# Altium schematic sheet AMS_IMD_Latching.SchDoc(AMS_IMD_Latching)
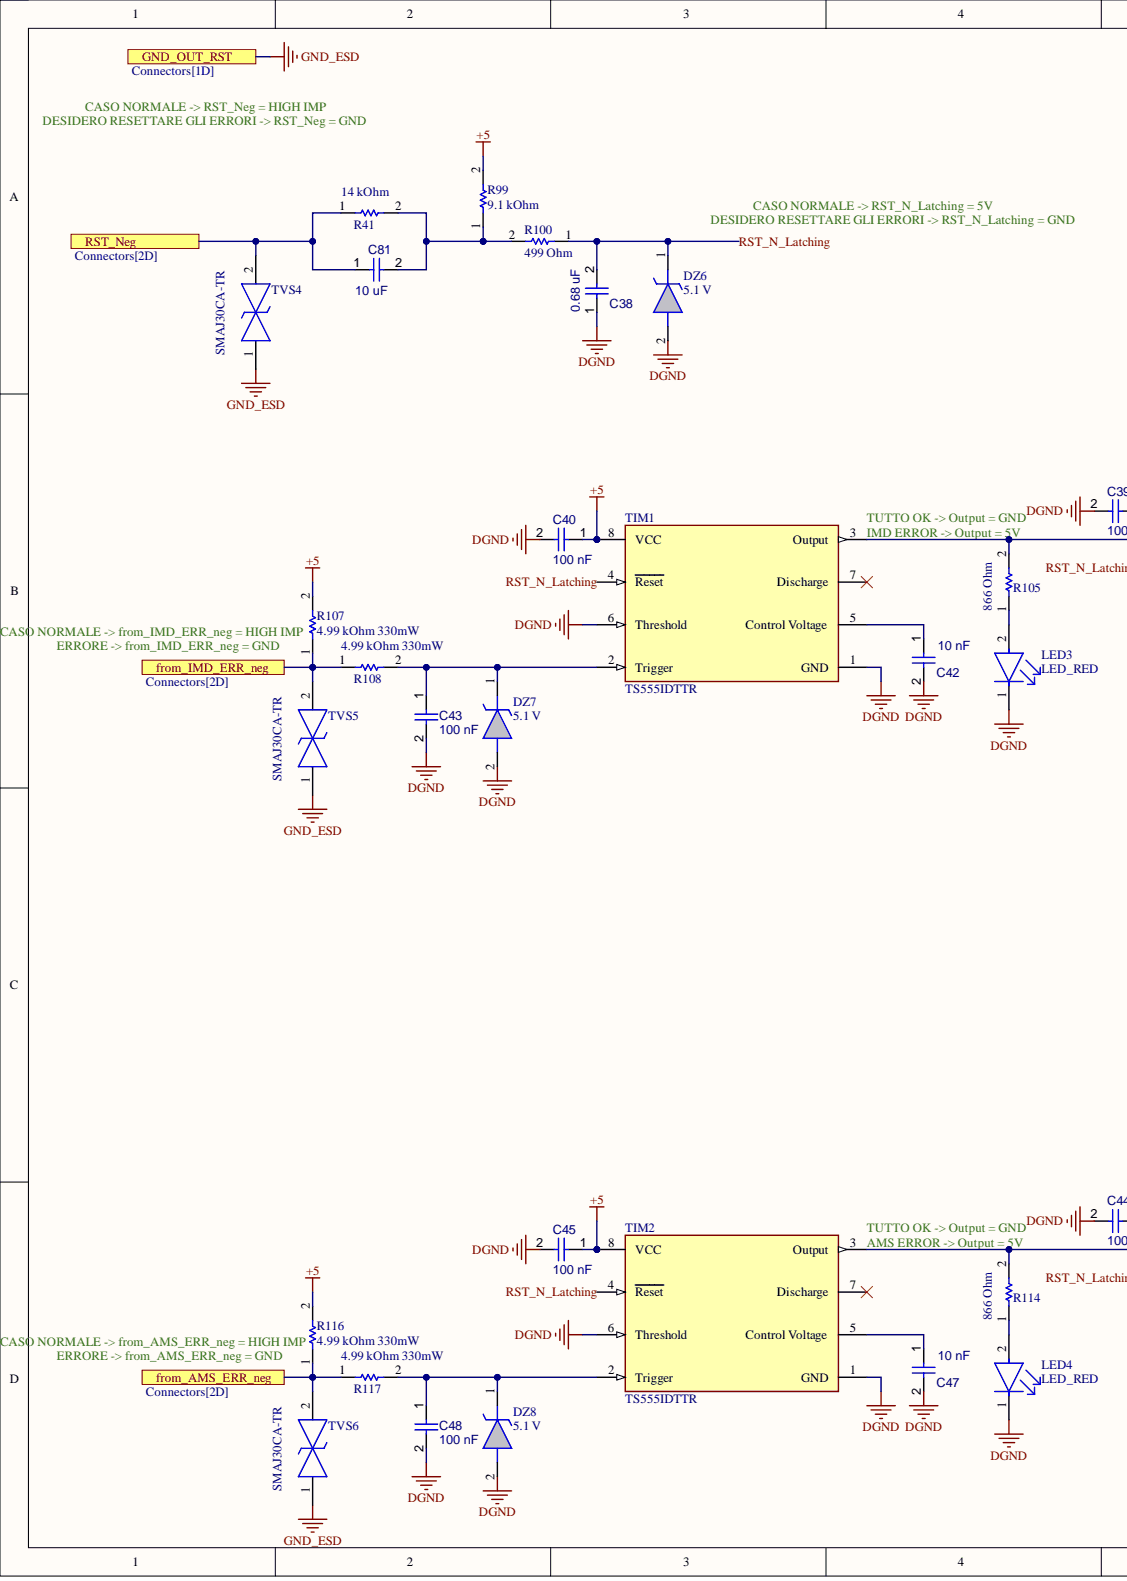
[diagram p0: AMS_IMD_Latching.SchDoc, left]
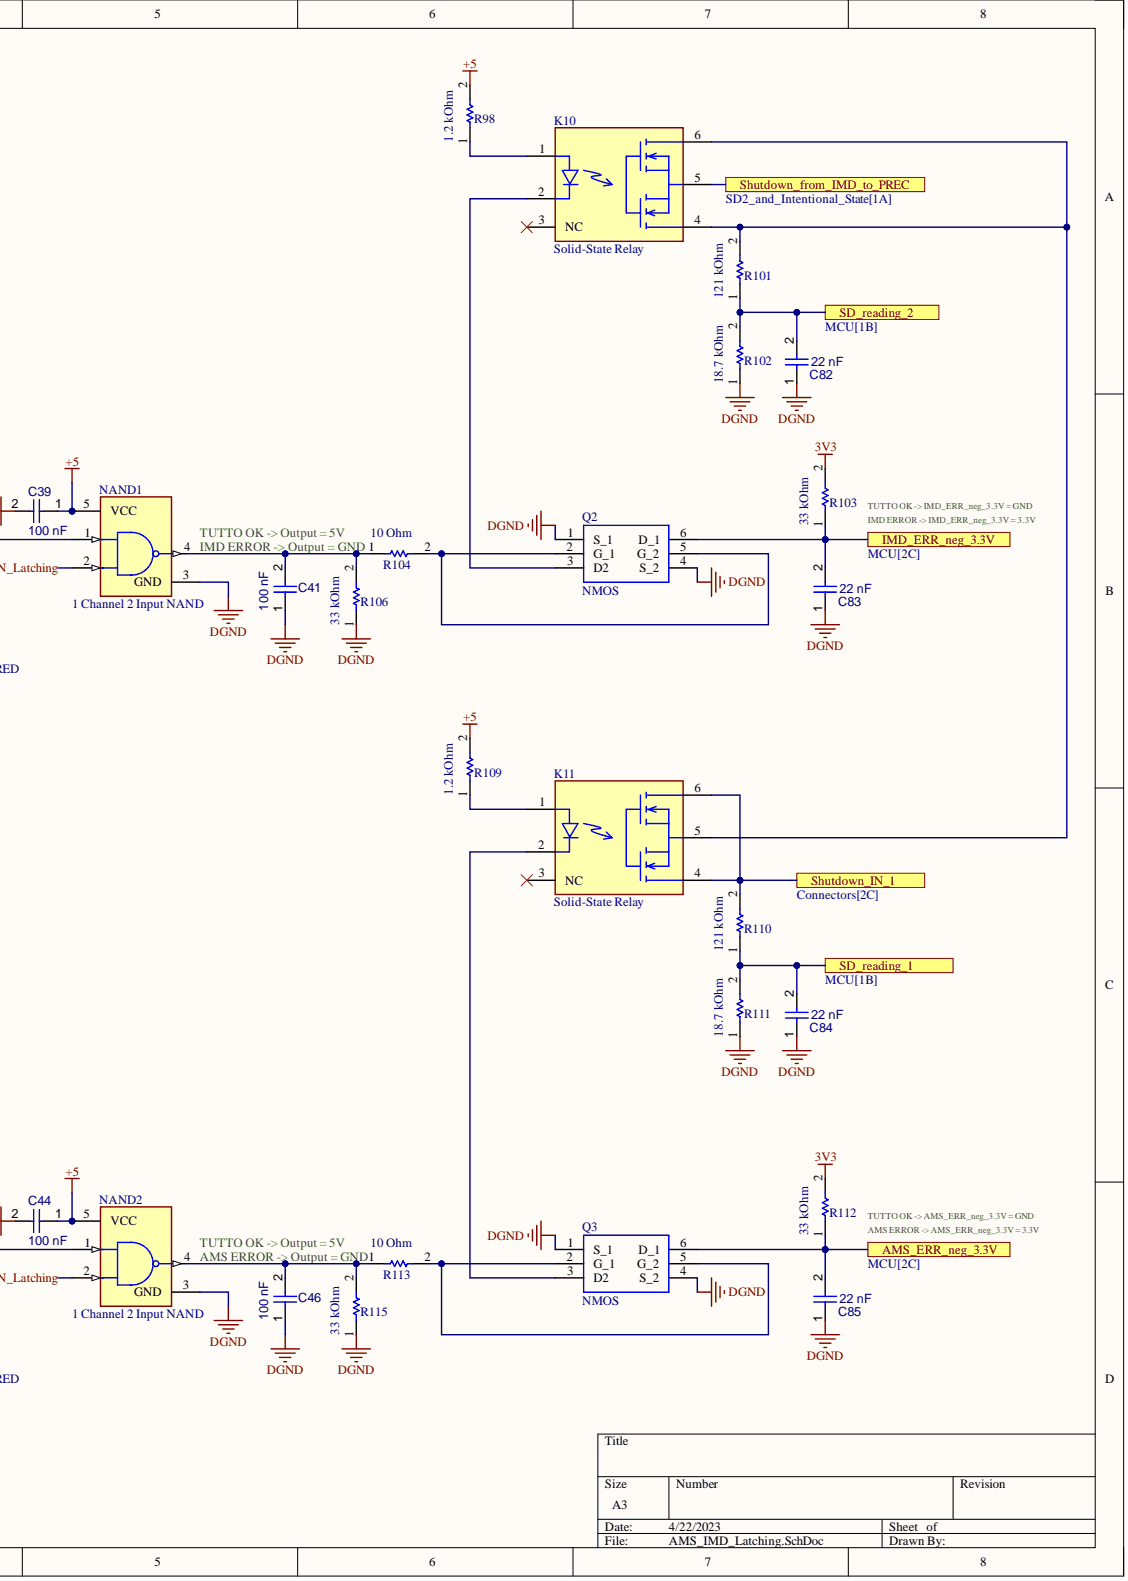
[diagram p1: AMS_IMD_Latching.SchDoc, right]

## Parts
C38  0.68 uF
C39  100 nF
C40  100 nF
C41  100 nF
C42  10 nF
C43  100 nF
C44  100 nF
C45  100 nF
C46  100 nF
C47  10 nF
C48  100 nF
C81  10 uF
C82  22 nF
C83  22 nF
C84  22 nF
C85  22 nF
DZ6  5.1 V
DZ7  5.1 V
DZ8  5.1 V
K10  Solid-State Relay  Category: Relay
K11  Solid-State Relay  Category: Relay
LED3  LED_RED
LED4  LED_RED
NAND1  1 Channel 2 Input NAND
NAND2  1 Channel 2 Input NAND
Q2  NMOS
Q3  NMOS
R41  14 kOhm
R98  1.2 kOhm
R99  9.1 kOhm
R100  499 Ohm
R101  121 kOhm
R102  18.7 kOhm
R103  33 kOhm
R104  10 Ohm
R105  866 Ohm
R106  33 kOhm
R107  4.99 kOhm 330mW
R108  4.99 kOhm 330mW
R109  1.2 kOhm
R110  121 kOhm
R111  18.7 kOhm
R112  33 kOhm
R113  10 Ohm
R114  866 Ohm
R115  33 kOhm
R116  4.99 kOhm 330mW
R117  4.99 kOhm 330mW
TIM1  TS555IDTTR
TIM2  TS555IDTTR
TVS4  SMAJ30CA-TR
TVS5  SMAJ30CA-TR
TVS6  SMAJ30CA-TR

## Ports
AMS_ERR_neg_3.3V, from_AMS_ERR_neg, from_IMD_ERR_neg, GND_OUT_RST, IMD_ERR_neg_3.3V, RST_Neg, SD_reading_1, SD_reading_2, Shutdown_from_IMD_to_PREC, Shutdown_IN_1

## Nets
3V3: R103.2 R112.2
+5: C39.1 C40.1 C44.1 C45.1 NAND1.5(VCC) NAND2.5(VCC) R98.2 R99.2 R107.2 R109.2 R116.2 TIM1.8(VCC) TIM2.8(VCC)
DGND: C38.1 C39.2 C40.2 C41.1 C42.2 C43.2 C44.2 C45.2 C46.1 C47.2 C48.2 C82.1 C83.1 C84.1 C85.1 DZ6.2 DZ7.2 DZ8.2 LED3.1 LED4.1 NAND1.3(GND) NAND2.3(GND) Q2.1(S_1) Q2.4(S_2) Q3.1(S_1) Q3.4(S_2) R102.1 R106.1 R111.1 R115.1 TIM1.1(GND) TIM1.6(Threshold) TIM2.1(GND) TIM2.6(Threshold)
AMS_ERR_neg_3.3V: C85.2 Q3.6(D_1) R112.1 ; port AMS_ERR_neg_3.3V
from_AMS_ERR_neg: R116.1 R117.1 TVS6.2 ; port from_AMS_ERR_neg
from_IMD_ERR_neg: R107.1 R108.1 TVS5.2 ; port from_IMD_ERR_neg
GND_ESD: TVS4.1 TVS5.1 TVS6.1 ; port GND_OUT_RST
IMD_ERR_neg_3.3V: C83.2 Q2.6(D_1) R103.1 ; port IMD_ERR_neg_3.3V
RST_N_Latching: C38.2 DZ6.1 NAND1.2 NAND2.2 R100.1 TIM1.4(Reset) TIM2.4(Reset)
RST_Neg: C81.1 R41.1 TVS4.2 ; port RST_Neg
SD_reading_1: C84.2 R110.1 R111.2 ; port SD_reading_1
SD_reading_2: C82.2 R101.1 R102.2 ; port SD_reading_2
Shutdown_from_IMD_to_PREC: K10.5 ; port Shutdown_from_IMD_to_PREC
Shutdown_IN_1: K11.4 K11.6 R110.2 ; port Shutdown_IN_1
NetC41_2: C41.2 NAND1.4 R104.1 R106.2
NetC42_1: C42.1 TIM1.5(Control_Voltage)
NetC43_1: C43.1 DZ7.1 R108.2 TIM1.2(Trigger)
NetC46_2: C46.2 NAND2.4 R113.1 R115.2
NetC47_1: C47.1 TIM2.5(Control_Voltage)
NetC48_1: C48.1 DZ8.1 R117.2 TIM2.2(Trigger)
NetC81_2: C81.2 R41.2 R99.1 R100.2
NetK10_1: K10.1 R98.1
NetK10_2: K10.2 Q2.3(D2)
NetK10_4: K10.4 K10.6 K11.5 R101.2
NetK11_1: K11.1 R109.1
NetK11_2: K11.2 Q3.3(D2)
NetLED3_2: LED3.2 R105.1
NetLED4_2: LED4.2 R114.1
NetNAND1_1: NAND1.1 R105.2 TIM1.3(Output)
NetNAND2_1: NAND2.1 R114.2 TIM2.3(Output)
NetQ2_2: Q2.2(G_1) Q2.5(G_2) R104.2
NetQ3_2: Q3.2(G_1) Q3.5(G_2) R113.2

Not connected: K10 3(NC); K11 3(NC); TIM1 7(Discharge); TIM2 7(Discharge)

## Library data of the parts
C38 = CMP-001-00085-2: VJ0805V684ZXXRW1BC; Tech: SMD; Component Kind: Standard; ComponentLink1Description: Website; ComponentLink1URL: https://www.mouser.it/ProductDetail/Vishay-Vitramon/VJ0805V684ZXXRW1BC?qs=Nei%2F%252Bwss3hi9BcSWgnck6Q%3D%3D; Package: 0805; Library Name: EMEA- Squadra Corse PoliTO-  Politecnico di Torino
C39-C41 C43-C46 C48 = CMP-001-00085-2: VJ0805Y104MXATW1BC; Tech: SMD; Component Kind: Standard; ComponentLink1Description: Website; ComponentLink1URL: https://www.mouser.it/ProductDetail/KYOCERA-AVX/08055C104KAT4A?qs=2ujN4bDBNE8aFT7CFyBStg%3D%3D; Package: 0805; Library Name: EMEA- Squadra Corse PoliTO-  Politecnico di Torino
C42 C47 = CMP-001-00085-2: VJ0805H103KXBBC31; Tech: SMD; Component Kind: Standard; ComponentLink1Description: Website; ComponentLink1URL: https://www.mouser.it/ProductDetail/Vishay-Vitramon/VJ0805H103KXBBC31?qs=ak4kh1ihuH4MS2NjAUlroQ%3D%3D; Package: 0805; Library Name: EMEA- Squadra Corse PoliTO-  Politecnico di Torino
C81 = CMP-001-00085-2: GRM21BR71A106KA73K; Tech: SMD; Component Kind: Standard; ComponentLink1Description: Website; ComponentLink1URL: https://www.mouser.it/ProductDetail/Vishay-Vitramon/VJ0805G106MXQTW1BC?qs=PqoDHHvF64%2FiIFVCZpIM5w%3D%3D; Package: 0805; Library Name: EMEA- Squadra Corse PoliTO-  Politecnico di Torino
C82-C85 = CMP-001-00085-2: VJ0805Y223JXACW1BC; Tech: SMD; Component Kind: Standard; ComponentLink1Description: Website; ComponentLink1URL: https://www.mouser.it/ProductDetail/Vishay-Vitramon/VJ0805Y223JXXPW1BC?qs=dYENeDlLSFWEZ4cdRF5phA%3D%3D; Package: 0805; Library Name: EMEA- Squadra Corse PoliTO-  Politecnico di Torino
DZ6-DZ8 = CMP-002-000008-1: MMSZ5231C-E3-08; Manufacturer_Name: Vishay; Geometry.Height: 1.35mm; Tech: SMD; Component Kind: Standard; ComponentLink1Description: Website; ComponentLink1URL: https://www.mouser.it/ProductDetail/Vishay-Semiconductors/MMSZ5231C-E3-08?qs=S660mHfF5o35EDHKMfkp8w%3D%3D; Datasheet Link: https://www.arrow.com/en/products/mmsz5231c-e3-08/vishay; Design Item ID: MMSZ5231C-E3-08; Manufacturer_Part_Number: MMSZ5231C-E3-08; Mouser Part Number: 78-MMSZ5231C-E3-08; Mouser Price/Stock: https://www.mouser.co.uk/ProductDetail/Vishay-Semiconductors/MMSZ5231C-E3-08?qs=S660mHfF5o35EDHKMfkp8w%3D%3D; Package: SOD-123-2; Source: MMSZ5231C-E3-08.SchLib; Library Name: EMEA- Squadra Corse PoliTO-  Politecnico di Torino
K10 K11 = CMP-2000-07398-2: VO14642AABTR; Manufacturer: Vishay; Input Current: 50mA; Isolation Voltage: 5300Vrms; Max Junction Temp: +125°C; Max Power Dissipation: 330mW; Number Of Contacts: 1; On State Resistance: 0.25Ω; Output Current: 2A; Output Voltage: 60V; Packag: SMD DIP-6; Standoff Height: 0.05mm; Tech: SMD; Throw Configuration: SPST-NO; Ambient Temperature Range High: +85°C; Ambient Temperature Range Low: -55°C; Automotive: No; Component Kind: Standard; ComponentLink2Description: Datasheet; ComponentLink2URL: http://www.vishay.com/docs/81646/vo14642a.pdf; ComponentLink3Description: Website; ComponentLink3URL: https://www.mouser.it/ProductDetail/Vishay-Semiconductors/VO14642AABTR?qs=%2Fjqivxn91cdjDkQHAFlOvw%3D%3D; Device Class L1: Electromechanical; Device Class L2: Relays; Device Class L3: Solid State Relays; Digikey Description: SSR RELAY SPST-NO 2A 0-60V; Height: 4.25mm; Ipc Land Pattern Name: SOIC254P980X425-6; Lead Free: Yes; Library Name: Altium Content Vault; Manufacturer Part Number: VO14642AABTR; Mouser Description: Solid State Relays - PCB Mount Normally Open Form 1A 60V; Octopart: https://octopart.com/vo14642aabtr-vishay-42763680; Package: VO14642AABTR; RoHS: TRUE; Temperature: to +125°C; Temperature Range High: +125°C
LED3 LED4 = CMP-007-00036-1: VLMS1300-GS08; Tech: SMD; Component Kind: Standard; ComponentLink1Description: Website; ComponentLink1URL: https://www.mouser.it/ProductDetail/Vishay-Semiconductors/VLMS1300-GS08?qs=uoVnq4yU%252B9LtZKILJuIDBQ%3D%3D; Package: 0603; Library Name: EMEA- Squadra Corse PoliTO-  Politecnico di Torino
NAND1 NAND2 = CMP-0859-00141-4: SN74AHCT1G00DBVR; High Level Output Current: -8mA; Logic Function: NAND GATE; Low Level Output Current: 8mA; Max Supply Voltage: 5.5V; Min Supply Voltage: 4.5V; Number of Bits: 1; Number of Input Lines: 2; Propagation Delay: 7.9ns; Quiescent Current: 1uA; Schmitt Trigger Input: No; Tech: SMD; Turn-On Delay Time: 9ns; Case/Package: SOT-23; Component Kind: Standard; ComponentLink1Description: Website; ComponentLink1URL: https://www.mouser.it/ProductDetail/Texas-Instruments/SN74AHCT1G00DBVR?qs=w32V8uFkMxnwwXcMH%2F61Dg%3D%3D; Contact Plating: Tin; Height: 1.15mm; Lead Free: Lead Free; Length: 2.9mm; Library Name: Altium Content Vault; Max Operating Temperature: 125°C; Max Output Current: 8mA; Min Operating Temperature: -40°C; Mount: Surface Mount; Number of Circuits: 1; Number of Elements: 1; Number of Gates: 1; Number of Inputs: 2; Number of Output Lines: 1; Number of Outputs: 1; Number of Pins: 5; Output Current: 8mA; Package: SOT-23-5; Package Quantity: 1; Packaging: Tape and Reel; Radiation Hardening: No; REACH SVHC: No SVHC; Termination: SMD/SMT; Weight: 0.000557oz; Width: 1.6mm
Q2 Q3 = CMP-069-000000-2: SI1926DL-T1-GE3; Tech: SMD; Component Kind: Standard; ComponentLink1Description: Website; ComponentLink1URL: https://www.mouser.it/ProductDetail/Vishay-Semiconductors/SI1926DL-T1-GE3?qs=FfLXECYddQSjYFoPhKd4yw%3D%3D; Package: SOT-363-6; Library Name: EMEA- Squadra Corse PoliTO-  Politecnico di Torino
R41 = CMP-009-00179-4: CRCW060314K0FKEA; Tech: SMD; Component Kind: Standard; ComponentLink1Description: Website; ComponentLink1URL: https://www.mouser.it/ProductDetail/Vishay-Dale/CRCW060314K0FKEA?qs=uKCD9FsNuRO8UT7qXshQQw%3D%3D; Package: 0603; Library Name: EMEA- Squadra Corse PoliTO-  Politecnico di Torino
R98 R109 = CMP-009-00179-4: CRCW06031K20FKEAHP; Tech: SMD; Component Kind: Standard; ComponentLink1Description: Website; ComponentLink1URL: https://www.mouser.it/ProductDetail/Vishay-Dale/CRCW06031K20FKEAHP?qs=40TFAHZMFveP9B2Fb67Ndg%3D%3D; Package: 0603; Library Name: EMEA- Squadra Corse PoliTO-  Politecnico di Torino
R99 = CMP-009-00179-4: CRCW06039K10FKEA; Tech: SMD; Component Kind: Standard; ComponentLink1Description: Website; ComponentLink1URL: https://www.mouser.it/ProductDetail/Vishay-Dale/CRCW06039K10FKEA?qs=GPtCTTl25eGLvLFu2DRXcw%3D%3D; Package: 0603; Library Name: EMEA- Squadra Corse PoliTO-  Politecnico di Torino
R100 = CMP-009-00179-4: CRCW0603499RFKEAHP; Tech: SMD; Component Kind: Standard; ComponentLink1Description: Website; ComponentLink1URL: https://www.mouser.it/ProductDetail/Vishay-Dale/CRCW0603499RFKEAHP?qs=k2%2FDWSARqgGdRUjwu2QzYw%3D%3D; Package: 0603; Library Name: EMEA- Squadra Corse PoliTO-  Politecnico di Torino
R101 R110 = CMP-009-00179-4: CRCW0603121KFKEA; Tech: SMD; Component Kind: Standard; ComponentLink1Description: Website; ComponentLink1URL: https://www.mouser.it/ProductDetail/Vishay-Dale/CRCW0603121KFKEA?qs=SNwsiWikH8rxaNKbUsRIDA%3D%3D; Package: 0603; Library Name: EMEA- Squadra Corse PoliTO-  Politecnico di Torino
R102 R111 = CMP-009-00179-4: CRCW060318K7FKEA; Tech: SMD; Component Kind: Standard; ComponentLink1Description: Website; ComponentLink1URL: https://www.mouser.it/ProductDetail/Vishay-Dale/CRCW060318K7FKEA?qs=9qnzKDpA3VA15sIAY%252B8syw%3D%3D; Package: 0603; Library Name: EMEA- Squadra Corse PoliTO-  Politecnico di Torino
R103 R106 R112 R115 = CMP-009-00179-4: RCS060333K0FKEA; Tech: SMD; Component Kind: Standard; ComponentLink1Description: Website; ComponentLink1URL: https://www.mouser.it/ProductDetail/Vishay-Dale/RCS060333K0FKEA?qs=yDQnu9hAfI9uIG1%252BkBaBdg%3D%3D; Package: 0603; Library Name: EMEA- Squadra Corse PoliTO-  Politecnico di Torino
R104 R113 = CMP-009-00179-4: CRCW060310R0FKEAHP; Tech: SMD; Component Kind: Standard; ComponentLink1Description: Website; ComponentLink1URL: https://www.mouser.it/ProductDetail/Vishay-Dale/CRCW060310R0FKEAHP?qs=k2%2FDWSARqgHKJ7AdmQKyZw%3D%3D; Package: 0603; Library Name: EMEA- Squadra Corse PoliTO-  Politecnico di Torino
R105 R114 = CMP-009-00179-4: CRCW0603866RFKEA; Tech: SMD; Component Kind: Standard; ComponentLink1Description: Website; ComponentLink1URL: https://www.mouser.it/ProductDetail/Vishay-Dale/CRCW0603866RFKEA?qs=wtJBjE46HQv%2FEQq4OglnCg%3D%3D; Package: 0603; Library Name: EMEA- Squadra Corse PoliTO-  Politecnico di Torino
R107 R108 R116 R117 = CMP-009-00179-4: CRCW06034K99FKEAHP; Tech: SMD; Component Kind: Standard; ComponentLink1Description: Website; ComponentLink1URL: https://www.mouser.it/ProductDetail/Vishay-Dale/CRCW06034K99FKEAHP?qs=k2%2FDWSARqgHklscWqsMlIA%3D%3D; Package: 0603; Library Name: EMEA- Squadra Corse PoliTO-  Politecnico di Torino
TIM1 TIM2 = CMP-12094-000001-1: Manufacturer: STMicroelectronics; Frequency: 2.7MHz; Max Junction Temp: +150°C; Max Supply Voltage: 16V; Min Supply Voltage: 2V; Nominal Supply Current: 65-170uA; Number Of Timers/Counters: 1; Propagation Delay: 100ns; Standoff Height: 0.1mm; Tech: SMD; Ambient Temperature Range High: +125°C; Ambient Temperature Range Low: -40°C; Automotive: No; Category: IC; Component Kind: Standard; ComponentLink2Description: Datasheet; ComponentLink2URL: https://www.st.com/resource/en/datasheet/ts555.pdf; ComponentLink3Description: Website; ComponentLink3URL: https://www.mouser.it/ProductDetail/STMicroelectronics/TS555IDTTR?qs=ypY7uveMeOSn6Z3Y4YsQ%252BQ%3D%3D; Description: TS555IDTTR; Device Class L1: Integrated Circuits (ICs); Device Class L2: Clock and Timing; Device Class L3: Timers and Oscillators; Digikey Description: IC OSC SINGLE TIMER 2.7MHZ 8SOIC; Footprint Url: https://www.st.com/resource/en/datasheet/stm8l001j3.pdf; Height: 1.75mm; Lead Free: Yes; Library Name: Altium Content Vault; Manufacturer Part Number: TS555IDTTR; Mouser Description: Standard Timer Single -40C 125C 8-Pin SO N T/R; Octopart: https://octopart.com/ts555idttr-stmicroelectronics-64758051; Package: SO8; RoHS: TRUE; Temperature: -40°C to +125°C; Temperature Range High: +125°C; Temperature Range Low: -40°C
TVS4-TVS6 = CMP-004-000005-1: TVS Diode Bi-Directional SMAJ30CA-TR 64.3V; Manufacturer_Name: STMicroelectronics; Arrow Part Number: SMAJ30CA-TR; Arrow Price/Stock: https://www.arrow.com/en/products/smaj30ca-tr/stmicroelectronics?region=nac; Component Kind: Standard; Datasheet Link: https://www.st.com/resource/en/datasheet/smaj10ca.pdf; Design Item ID: SMAJ30CA-TR; Height: 2.65mm; Manufacturer_Part_Number: SMAJ30CA-TR; Mouser Part Number: 511-SMAJ30CA-TR; Mouser Price/Stock: https://www.mouser.co.uk/ProductDetail/STMicroelectronics/SMAJ30CA-TR?qs=0TJjK1waviDY0EkLaKH12g%3D%3D; Source: SamacSys.SchLib; Library Name: EMEA- Squadra Corse PoliTO-  Politecnico di Torino
